# Altium schematic sheet USB_IN_OUT.SchDoc
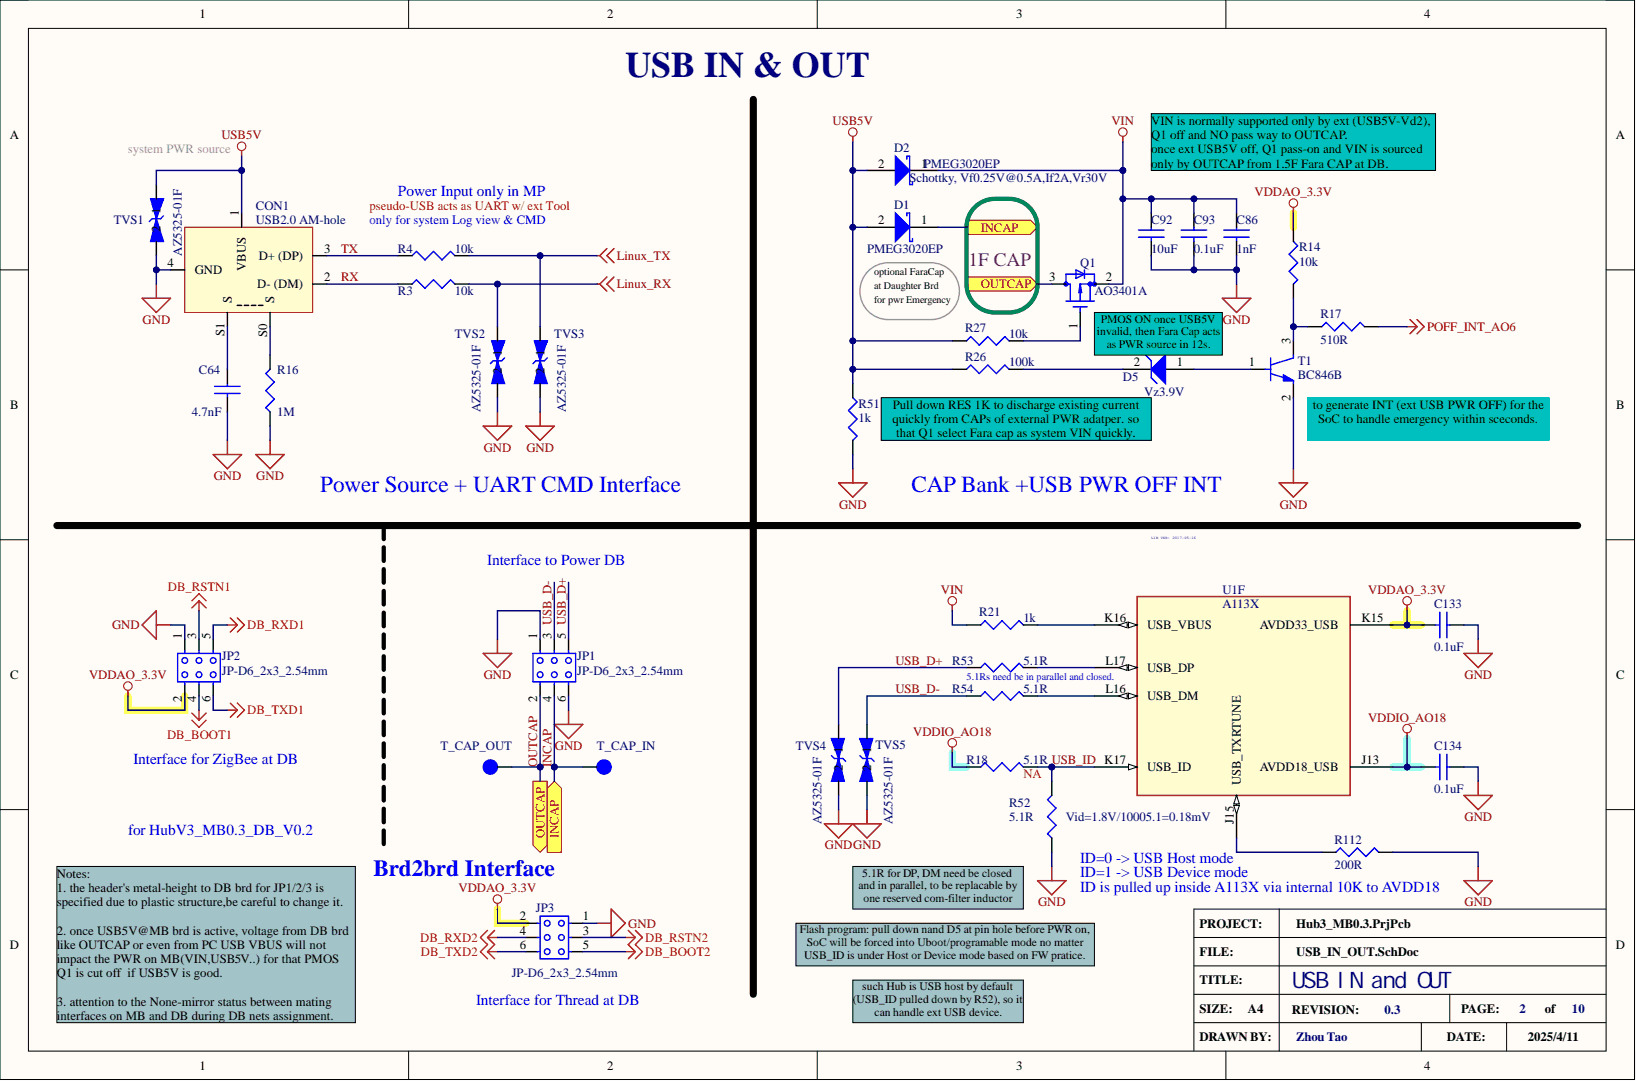
[diagram p0: USB_IN_OUT.SchDoc]

## Parts
C64  4.7nF  [C0402]
C86  1nF  [C0402]
C92  10uF  [C0603]
C93  0.1uF  [C0402]
C133  0.1uF  [C0201]
C134  0.1uF  [C0201]
CON1  USB2.0 AM-hole  [USB 2.0 AM]
D1  PMEG3020EP  [SOD128]
D2  PMEG3020EP  Des: Schottky, Vf0.25V@0.5A,If2A,Vr30V  [SOD128]
D5  Vz3.9V  [Diode_Zener_SOD323]
JP1  JP-D6_2x3_2.54mm  [DIPD6]
JP2  JP-D6_2x3_2.54mm  [DIPD6]
JP3  JP-D6_2x3_2.54mm  [DIPD6]
Q1  AO3401A  [SOT23_3D]
R3  10k  [R0402]
R4  10k  [R0402]
R14  10k  [R0402]
R16  1M  [R0402]
R17  510R  [R0402]
R18  NA  value 5.1R  [R0402]  (no BOM)
R21  1k  [R0402]
R26  100k  [R0402]
R27  10k  [R0402]
R51  1k  [R0402]
R52  5.1R  [R0402]
R53  5.1R  [R0402]
R54  5.1R  [R0402]
R112  value 200R  [R0402]  (hidden comment: RES_200R_0402_1%)
T1  BC846B  [SOT23_3D]
T_CAP_IN  T_POINT_D1.2mm  [TP_D1.2mm]  (value not drawn)  (no BOM)
T_CAP_OUT  T_POINT_D1.2mm  [TP_D1.2mm]  (value not drawn)  (no BOM)
TVS1  AZ5325-01F  [ESD0402]
TVS2  AZ5325-01F  [ESD0402]
TVS3  AZ5325-01F  [ESD0402]
TVS4  AZ5325-01F  [ESD0402]
TVS5  AZ5325-01F  [ESD0402]
U1  A113X  [A113X_284]  (part F)

## Ports
INCAP, OUTCAP

## Nets
DB_BOOT1: JP2.4
DB_BOOT2: JP3.5
DB_RSTN1: JP2.3
DB_RSTN2: JP3.3
DB_RXD1: JP2.5
DB_RXD2: JP3.4
DB_TXD1: JP2.6
DB_TXD2: JP3.6
GND: C64.2 C86.2 C92.2 C93.2 C133.1 C134.1 CON1.4(GND) JP1.1 JP1.6 JP2.1 JP3.1 R16.2 R51.2 R52.1 R112.2 T1.2 TVS1.2 TVS2.2 TVS3.2 TVS4.2 TVS5.2
Linux_RX: R3.2 TVS2.1
Linux_TX: R4.2 TVS3.1
POFF_INT_AO6: R17.2
USB5V: CON1.1(VBUS) D1.2 D2.2 R26.1 R27.1 R51.1 TVS1.1
VDDAO_3.3V: C133.2 JP2.2 JP3.2 R14.1 U1.K15(AVDD33_USB)
VDDIO_AO18: C134.2 R18.1 U1.J13(AVDD18_USB)
VIN: C86.1 C92.1 C93.1 D2.1 Q1.2 R21.1
INCAP: D1.1 JP1.4 T_CAP_IN.1 ; port INCAP
OUTCAP: JP1.2 Q1.3 T_CAP_OUT.1 ; port OUTCAP
RX: CON1.2(D-_(DM)) R3.1
TX: CON1.3(D+_(DP)) R4.1
USB_D+: JP1.5 R53.1 TVS4.1
USB_D-: JP1.3 R54.1 TVS5.1
USB_ID: R18.2 R52.2 U1.K17(USB_ID)
NetC64_1: C64.1 CON1.S1(S)
NetCON1_S0: CON1.S0(S_D-) R16.1
NetD5_1: D5.1 T1.1
NetD5_2: D5.2 R26.2
NetQ1_1: Q1.1 R27.2
NetR14_2: R14.2 R17.1 T1.3
NetR21_2: R21.2 U1.K16(USB_VBUS)
NetR53_2: R53.2 U1.L17(USB_DP)
NetR54_2: R54.2 U1.L16(USB_DM)
NetR112_1: R112.1 U1.J15(USB_TXRTUNE)

## Library data of the parts
C64 = Cap_4.7nF_0402_50V: Manufacturer: Yageo; Part Number: AC0402KRX7R9BB472; Des: 4.7nF,0402,50V,10%,X7R; Component Kind: Standard; Library Name: BL706NLv0.1.SchLib; value: 4.7nF
C86 = Cap_1nF_0402_50V: Manufacturer: EYANG; Part Number: C0402X7R102K500NTB; Des: 1nF,0402,50V,10%,X7R; Component Kind: Standard; value: 1nF; Library Name: Lib_sch_sisv3_2332.SchLib
C92 = Cap_10uF_0603_10%_10V: Manufacturer: Samsung; Part Number: CL10A106KP8NNNC; Des: 10uF,0603,X5R, 10%, 10V; Component Kind: Standard; value: 10uF; Library Name: Lib_sch_Hubv3_2332.SchLib
C93 = Cap_0.1uF_0402_10%_16V: Manufacturer: VIIYONG; Part Number: V104K0402X7R160NBT; Des: 0.1uF,0402,16V,X7R,10%; Component Kind: Standard; value: 0.1uF; Library Name: Lib_sch_Hubv3_2332.SchLib
C133 C134 = Cap_0.1uF_0201_16V: Manufacturer: EYANG; Part Number: C0201X5R104K160NTA; Des: 0.1uF,0201,16V,X5R,10%; Component Kind: Standard; value: 0.1uF; Library Name: Lib_sch_Hubv3_2332.SchLib
CON1 = USB2.0 AM-hole: Manufacturer: 艾唯; Part Number: C2680夹板HZP-AMLX-PA02-13.8; Des: USB A male through hole, short 14mm; value: USB 2.0 A 公头13.8 夹板 15.8×12×4.5mm 过盐雾试验; Component Kind: Standard; Library Name: Lib_sch_Hubv3_2332.SchLib
D1 = Diode_Schottky_0.36Vf_2A: Manufacturer: Nexperia; Des: Schottky, Vf0.25V@0.5A,If2A,Vr30V; Component Kind: Standard; Part Number: PMEG3020EP; value: PMEG3020EP; Library Name: Lib_sch_Hubv3_2332.SchLib
D2 = Diode_Schottky_0.36Vf_2A: Manufacturer: Nexperia; Component Kind: Standard; Part Number: PMEG3020EP; value: PMEG3020EP; Library Name: Lib_sch_Hubv3_2332.SchLib
D5 = Diode_Zener_3.9V: Manufacturer: YANGJIE; Part Number: 14M-BZT52B3V9S; Des: Zenner,SOD-323,Vz3.9V, Zzt95, Zzk600, Ir20uA; Component Kind: Standard; value: Vz3.9V; Library Name: Lib_sch_spk_V1_2020604.SchLib
JP1-JP3 = JP-D6_2x3_2.54mm: Manufacturer: 深圳国致or其它; Part Number: 2x3特定2.54双排针，座高=[11.5, 14]mm; Des: 2x3 2.54mm间距, 焊接后的座高=塑高+针高,上下板接; Value: 2x3特定双排针，座高=[11.5, 14]mm; Component Kind: Standard; Library Name: Lib_sch_Hubv3_2332.SchLib
Q1 = AO3401A: Manufacturer: AOS; Des: PMOS,30V,4A,85moh@2.5V; Component Kind: Standard; Part Number: AO3401A; value: AO3401A; Library Name: Lib_sch_Hubv3_2332.SchLib
R3 R4 R14 R27 = RES_10k_0402_1%: Manufacturer: Yageo; part number: RC0402FR-0710KL; Des: 10k,0402,1%; Component Kind: Standard; value: 10k; Library Name: Lib_sch_spk_V1_2020604.SchLib
R16 = RES_1M_0402_5%: Manufacturer: Yageo; part number: AC0402JR-071ML; Des: 1M,0402,5%,1/16w; Component Kind: Standard; Library Name: BL706NLv0.1.SchLib; value: 1M
R17 = RES_510R_0402_1%: Manufacturer: Yageo; part number: RC0402FR-07510RL; Des: 510R,0402,1%,1/16w; Component Kind: Standard; value: 510R; Library Name: Lib_sch_Hubv3_2332.SchLib
R18 R52-R54 = RES_5.1R_0402_1%: Manufacturer: Yageo; part number: RC0402FR-075R1L; Des: 5.1R,0402,1%,1/16w; Library Name: Lib_sch_Hubv3_2332.SchLib
  R52-R54: Component Kind: Standard; value: 5.1R
R21 R51 = RES_1k_0402_1%: Manufacturer: Yageo; part number: RC0402FR-071KL; Des: 1k,0402,1%,1/16w; Component Kind: Standard; value: 1k
  R21: Library Name: schlib_WBZ_hub.SchLib
  R51: Library Name: Lib_sch_spk_V1_2020604.SchLib
R26 = RES_100k_0402_1%: Manufacturer: Yageo; part number: RC0402FR-07100KL; Des: 100k,0402,1%,1/16w; Component Kind: Standard; value: 100k; Library Name: Lib_sch_spk_V1_2020604.SchLib
R112 = RES_200R_0402_1%: Manufacturer: Yageo; part number: RC0402FR-07200RL; Des: 200R,0402,1%,1/16w; Component Kind: Standard; Library Name: Lib_sch_spk_V0_180613.SchLib
T1 = BC846B: Manufacturer: ON Semi; Part Number: BC846BLT1G; Des: BJT, NPN, 65V, 100mA, 100MHz; value: NPN, BC846B; Component Kind: Standard; Library Name: Lib_sch_Hubv3_2332.SchLib
T_CAP_IN T_CAP_OUT = T_POINT_D1.2mm: Des: circle test pad (Dia=1.2mm ); Library Name: Lib_sch_spk_V0_181010.SchLib
TVS1-TVS5 = TVS DIODE_AZ5325-01F: Manufacturer: Amazing; Des: 0.5pF Cin, VBR7V,5V,25V ESD clamping @8kV; Component Kind: Standard; Part Number: AZ5325-01F; value: AZ5325-01F; Library Name: Lib_sch_Hubv3_2332.SchLib
U1 = A113X_20170516_V17: Manufacturer: AmLogic; Des: A113X, BGA,V17; Component Kind: Standard; Part Number: A113X; value: A113X; Library Name: Lib_sch_Hubv3_2332.SchLib
